# Altium schematic sheet Main.SchDoc
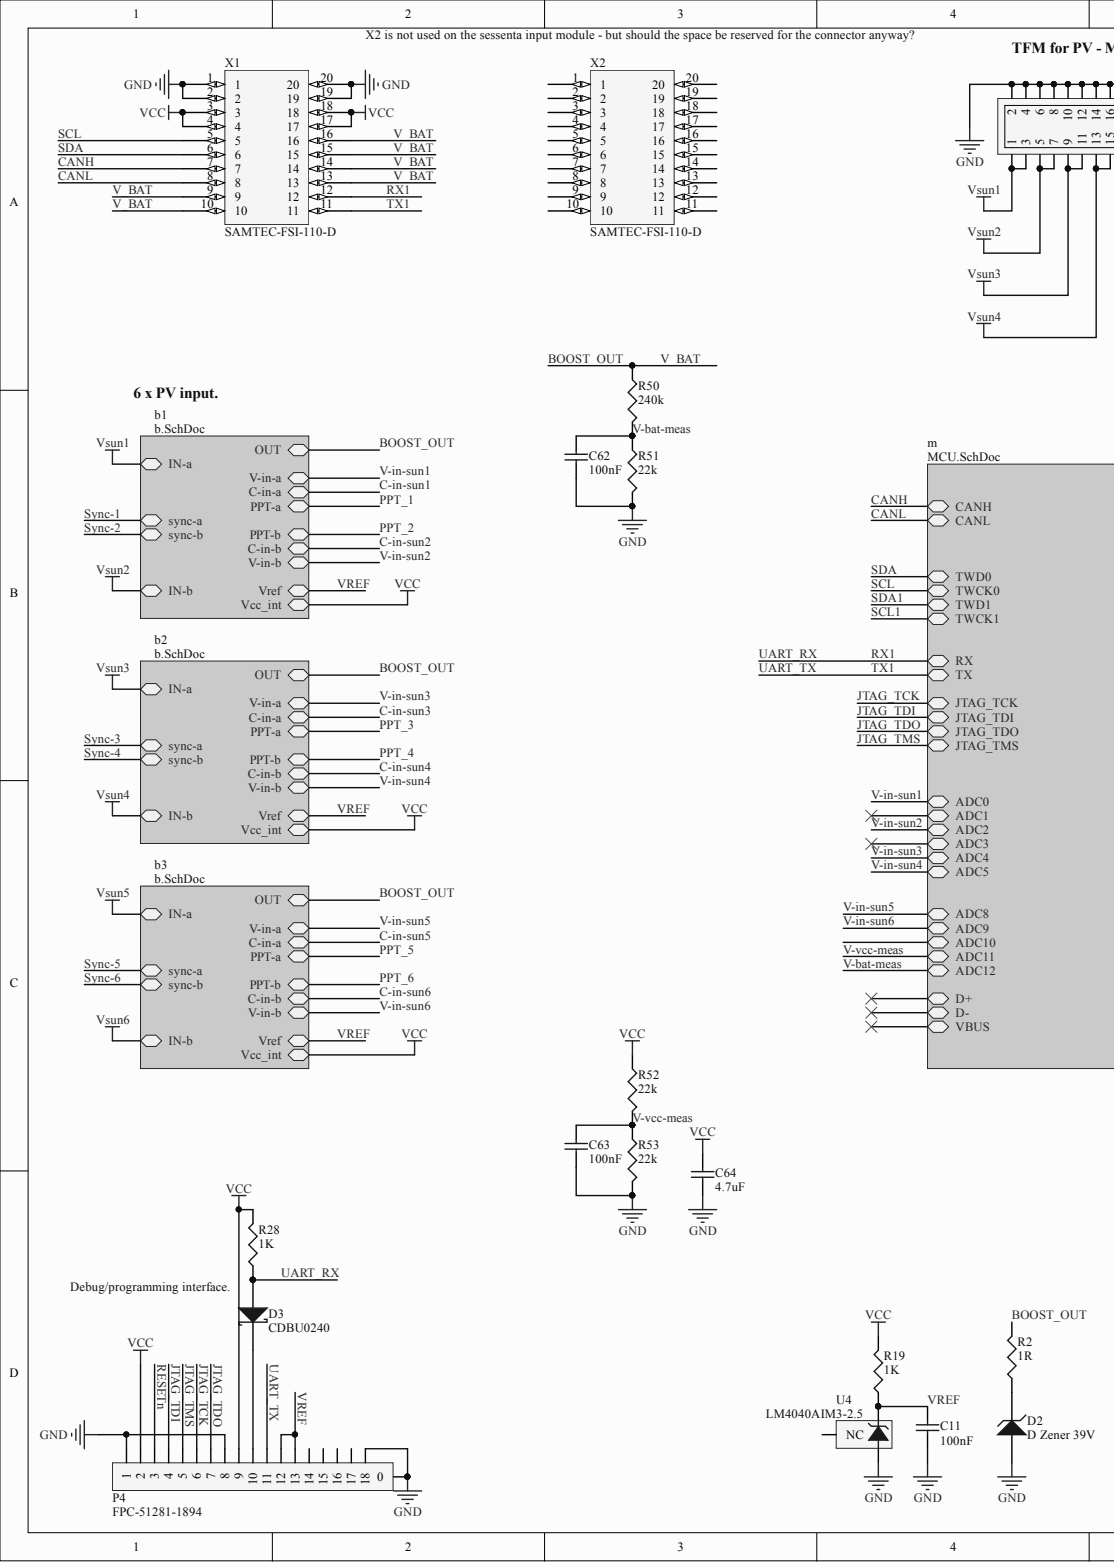
[diagram p0: Main.SchDoc, left]
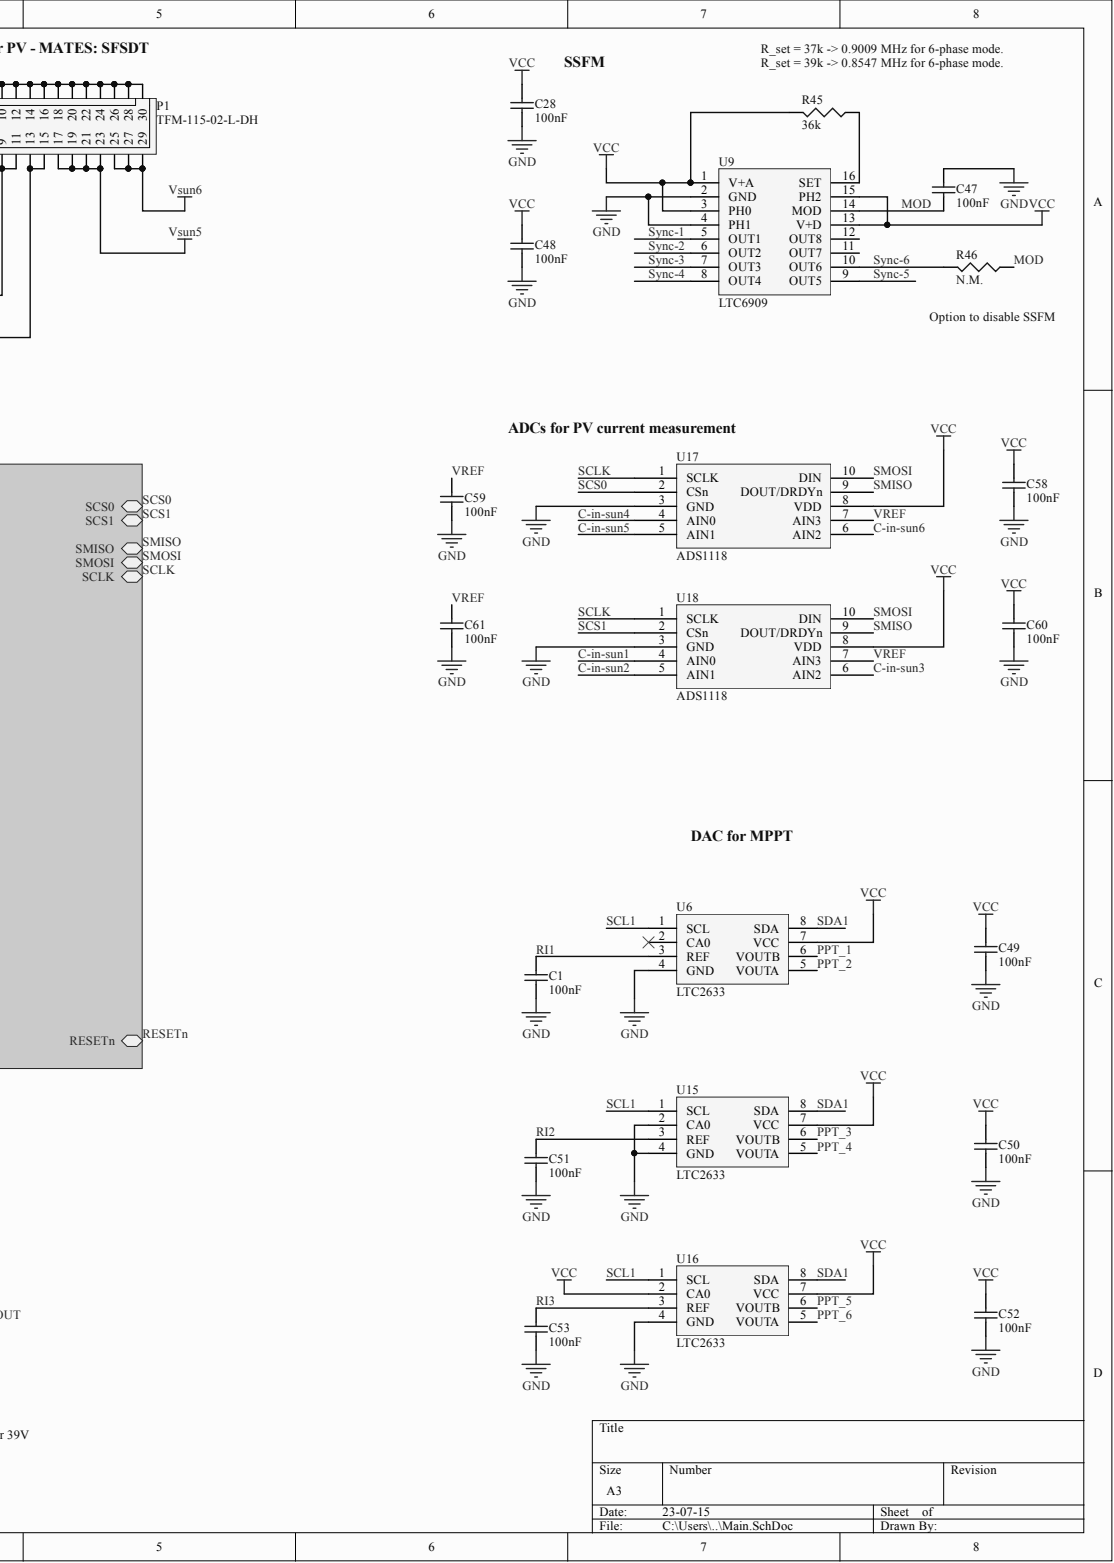
[diagram p1: Main.SchDoc, right]

## Parts
C1  value 100nF  [0402]  (hidden comment: Cap Semi)
C11  value 100nF  [0402]  (hidden comment: Cap Semi)
C28  value 100nF  [0402]  (hidden comment: Cap Semi)
C47  value 100nF  [0402]  (hidden comment: Cap Semi)
C48  value 100nF  [0402]  (hidden comment: Cap Semi)
C49  value 100nF  [0402]  (hidden comment: Cap Semi)
C50  value 100nF  [0402]  (hidden comment: Cap Semi)
C51  value 100nF  [0402]  (hidden comment: Cap Semi)
C52  value 100nF  [0402]  (hidden comment: Cap Semi)
C53  value 100nF  [0402]  (hidden comment: Cap Semi)
C58  value 100nF  [0402]  (hidden comment: Cap Semi)
C59  value 100nF  [0402]  (hidden comment: Cap Semi)
C60  value 100nF  [0402]  (hidden comment: Cap Semi)
C61  value 100nF  [0402]  (hidden comment: Cap Semi)
C62  value 100nF  [0402]  (hidden comment: Cap Semi)
C63  value 100nF  [0402]  (hidden comment: Cap Semi)
C64  value 4.7uF  [1608[0603]]  (hidden comment: Cap Semi)
D2  D Zener 39V  [0603]
D3  CDBU0240  [CD1608-0603]
P1  TFM-115-02-L-DH  [TFM-115-02-X-DH]
P4  FPC-51281-1894  [51281-1894]
R2  value 1R  [J1-0603]  (hidden comment: Res3)
R19  value 1K  [0402]  (hidden comment: Res3)
R28  value 1K  [0402]  (hidden comment: Res3)
R45  value 36k  [0402]  (hidden comment: Res3)
R46  value N.M.  [0402]  (hidden comment: Res3)
R50  value 240k  [0402]  (hidden comment: Res3)
R51  value 22k  [0402]  (hidden comment: Res3)
R52  value 22k  [0402]  (hidden comment: Res3)
R53  value 22k  [0402]  (hidden comment: Res3)
U4  LM4040AIM3-2.5  [SOT23]
U6  LTC2633  [TSOT23-8]
U9  LTC6909  [MSOP-16]
U15  LTC2633  [TSOT23-8]
U16  LTC2633  [TSOT23-8]
U17  ADS1118  [MSOP-10]
U18  ADS1118  [MSOP-10]
X1  SAMTEC-FSI-110-D  [SAMTEC-FSI-110-D]
X2  SAMTEC-FSI-110-D  [SAMTEC-FSI-110-D]

## Nets
GND: C1.1 C11.1 C28.1 C47.2 C48.1 C49.1 C50.1 C51.1 C52.1 C53.1 C58.1 C59.1 C60.1 C61.1 C62.1 C63.1 C64.1 D2.1 P1.2 P1.4 P1.6 P1.8 P1.10 P1.12 P1.14 P1.16 P1.18 P1.20 P1.22 P1.24 P1.26 P1.28 P1.30 P4.0 P4.1 P4.8 P4.18 R51.2 R53.2 U4.2 U6.4(GND) U9.2(GND) U9.4(PH1) U15.2(CA0) U15.4(GND) U16.4(GND) U17.3(GND) U18.3(GND) X1.1 X1.2 X1.19 X1.20
VCC: C28.2 C48.2 C49.2 C50.2 C52.2 C58.2 C60.2 C64.2 P4.2 P4.9 R19.2 R28.2 R45.2 R52.1 U6.7(VCC) U9.1(V+A) U9.3(PH0) U9.13(V+D) U9.15(PH2) U15.7(VCC) U16.2(CA0) U16.7(VCC) U17.8(VDD) U18.8(VDD) X1.3 X1.4 X1.17 X1.18
VSUN1: P1.1 P1.3
VSUN2: P1.5 P1.7
VSUN3: P1.9 P1.11
VSUN4: P1.13 P1.15
VSUN5: P1.17 P1.19 P1.21 P1.23
VSUN6: P1.25 P1.27 P1.29
BOOST_OUT: R2.2 R50.1 X1.9 X1.10 X1.13 X1.14 X1.15 X1.16
C-IN-SUN1: U18.4(AIN0)
C-IN-SUN2: U18.5(AIN1)
C-IN-SUN3: U18.6(AIN2)
C-IN-SUN4: U17.4(AIN0)
C-IN-SUN5: U17.5(AIN1)
C-IN-SUN6: U17.6(AIN2)
CANH: X1.7
CANL: X1.8
JTAG_TCK: P4.6
JTAG_TDI: P4.4
JTAG_TDO: P4.7
JTAG_TMS: P4.5
MOD: C47.1 R46.1 U9.14(MOD)
PPT_1: U6.6(VOUTB)
PPT_2: U6.5(VOUTA)
PPT_3: U15.6(VOUTB)
PPT_4: U15.5(VOUTA)
PPT_5: U16.6(VOUTB)
PPT_6: U16.5(VOUTA)
RESETN: P4.3
RI1: C1.2 U6.3(REF)
RI2: C51.2 U15.3(REF)
RI3: C53.2 U16.3(REF)
RX1: D3.1 R28.1 X1.12
SCL: X1.5
SCL1: U6.1(SCL) U15.1(SCL) U16.1(SCL)
SCLK: U17.1(SCLK) U18.1(SCLK)
SCS0: U17.2(CSn)
SCS1: U18.2(CSn)
SDA: X1.6
SDA1: U6.8(SDA) U15.8(SDA) U16.8(SDA)
SMISO: U17.9(DOUT/DRDYn) U18.9(DOUT/DRDYn)
SMOSI: U17.10(DIN) U18.10(DIN)
SYNC-1: U9.5(OUT1)
SYNC-2: U9.6(OUT2)
SYNC-3: U9.7(OUT3)
SYNC-4: U9.8(OUT4)
SYNC-5: U9.9(OUT5)
SYNC-6: R46.2 U9.10(OUT6)
TX1: P4.11 X1.11
V-BAT-MEAS: C62.2 R50.2 R51.1
V-VCC-MEAS: C63.2 R52.2 R53.1
VREF: C11.2 C59.2 C61.2 P4.12 P4.13 R19.1 U4.1 U17.7(AIN3) U18.7(AIN3)
NetD2_2: D2.2 R2.1
NetD3_2: D3.2 P4.10
NetP4_14: P4.14
NetP4_15: P4.15
NetP4_16: P4.16
NetP4_17: P4.17
NetR45_1: R45.1 U9.16(SET)
NetU4_3: U4.3
NetU6_2: U6.2(CA0)
NetU9_11: U9.11(OUT7)
NetU9_12: U9.12(OUT8)
NetX2_1: X2.1
NetX2_2: X2.2
NetX2_3: X2.3
NetX2_4: X2.4
NetX2_5: X2.5
NetX2_6: X2.6
NetX2_7: X2.7
NetX2_8: X2.8
NetX2_9: X2.9
NetX2_10: X2.10
NetX2_11: X2.11
NetX2_12: X2.12
NetX2_13: X2.13
NetX2_14: X2.14
NetX2_15: X2.15
NetX2_16: X2.16
NetX2_17: X2.17
NetX2_18: X2.18
NetX2_19: X2.19
NetX2_20: X2.20
ADC1:
ADC3:
ADC10:
D+:
D-:
V-IN-SUN1:
V-IN-SUN2:
V-IN-SUN3:
V-IN-SUN4:
V-IN-SUN5:
V-IN-SUN6:
VBUS:

## Library data of the parts
C1 C11 C28 C47-C53 C58-C64 = Cap Semi: Capacitor (Semiconductor SIM Model); Component Kind: Standard; LatestRevisionDate: 29-May-2009; LatestRevisionNote: IPC-7351 Footprint Added.; Library Name: Miscellaneous Devices.IntLib; PackageDescription: Chip Capacitor; PackageReference: C1206; Published: 8-Jun-2000; Publisher: Altium Limited; Signal Integrity: Cap Semi; Simulation: CAP
D2 = D Zener: Zener Diode; Component Kind: Standard; LatestRevisionDate: 17-Jul-2002; LatestRevisionNote: Re-released for DXP Platform.; Library Name: Miscellaneous Devices.IntLib; PackageDescription: Diode, Thru-Hole; 2 Leads; 0.7 in Pin Spacing; PackageReference: DIODE-0.7; Published: 8-Jun-2000; Publisher: Altium Limited; Signal Integrity: D Zener; Simulation: ZENER
D3 = D Schottky: Schottky Diode; Code_JEDEC: DO-214-AA; Component Kind: Standard; LatestRevisionDate: 17-Jul-2002; LatestRevisionNote: Re-released for DXP Platform.; Library Name: Miscellaneous Devices.IntLib; PackageReference: SMB; Published: 8-Jun-2000; Publisher: Altium Limited; Signal Integrity: D Schottky; Simulation: SKYDIODE
P1 = TFM-115-02-L-DH: 15 pos 2 row 30 pin TFM, samtec; Component Kind: Standard; Library Name: sessentas.SchLib; PartNumber: TFM-115-02-L-DH
P4 = FPC-51281-1894: FPC, 0.5mm pitch, Molex, 1.2mm height.; Component Kind: Standard; Library Name: sessentas.SchLib; PartNumber: 51281-1894
R2 R19 R28 R45 R46 R50-R53 = Res3: Resistor; Component Kind: Standard; LatestRevisionDate: 29-May-2009; LatestRevisionNote: IPC-7351 Footprint Added.; Library Name: Miscellaneous Devices.SchLib; PackageDescription: Chip Resistor; PackageReference: J1-0603; Published: 8-Jun-2000; Publisher: Altium Limited; Signal Integrity: Res; Simulation: RESISTOR
U4 = LM4040AIM3-2.5: Precision Micropower Shunt Voltage Reference; Manufacturer: National Semiconductor; CompType: Voltage Reference IC; PackageTopMarking: R2A*XTT; PowerWise: N; Component Kind: Standard; ComponentLink1Description: LM4040 Datasheet; ComponentLink1URL: http://www.national.com/ds/LM/LM4040.pdf; ComponentLink2Description: LM4040 Web Page; ComponentLink2URL: http://www.national.com/pf/LM/LM4040.html; ComponentLink3Description: MF03A Package Page; ComponentLink3URL: http://www.national.com/packaging/folders/mf03a.html; DatasheetVersion: Apr-2005; DigiKeyPartNumber: LM4040AIM3-2.5CT-ND; Library Name: NSC Voltage Reference.IntLib; PackageDescription: Molded SOT-23, .115 x .051 x .037in Body, 3 Lead, .075 in Pitch; PackageReference: MF03A; PackageVersion: revB, Mar-2003; PartNumber: LM4040AIM3-2.5; PinCount: 3; Published: 4-Dec-2008; Publisher: Altium Limited; RoHS: O
U6 U15 U16 = LTC2633: Dual 12-/10-/8-Bit I2C  VOUT DACs with  10ppm/°C Reference; PartNumber: LTC2633HTS8-LO10#TRM; Component Kind: Standard; Library Name: sessentas.SchLib
U9 = LTC6909: 1 to 8 Output, Multiphase  Silicon Oscillator with Spread  Spectrum Modulation; PartNumber: LTC6909HMS#PBF; Component Kind: Standard; Library Name: sessentas.SchLib
U17 U18 = ADS1118: 16-Bit  Analog-to-Digital Converter and Temperature Sensor with Internal Reference; Manufacture: Texas Instruments; PartNumber: ADS1118IDGST; Component Kind: Standard; Library Name: sessentas.SchLib
X1 X2 = SAMTEC-FSI-110-D: Component Kind: Standard; Library Name: Johan.SCHLIB
